# Altium schematic sheet NET.SchDoc(NET)
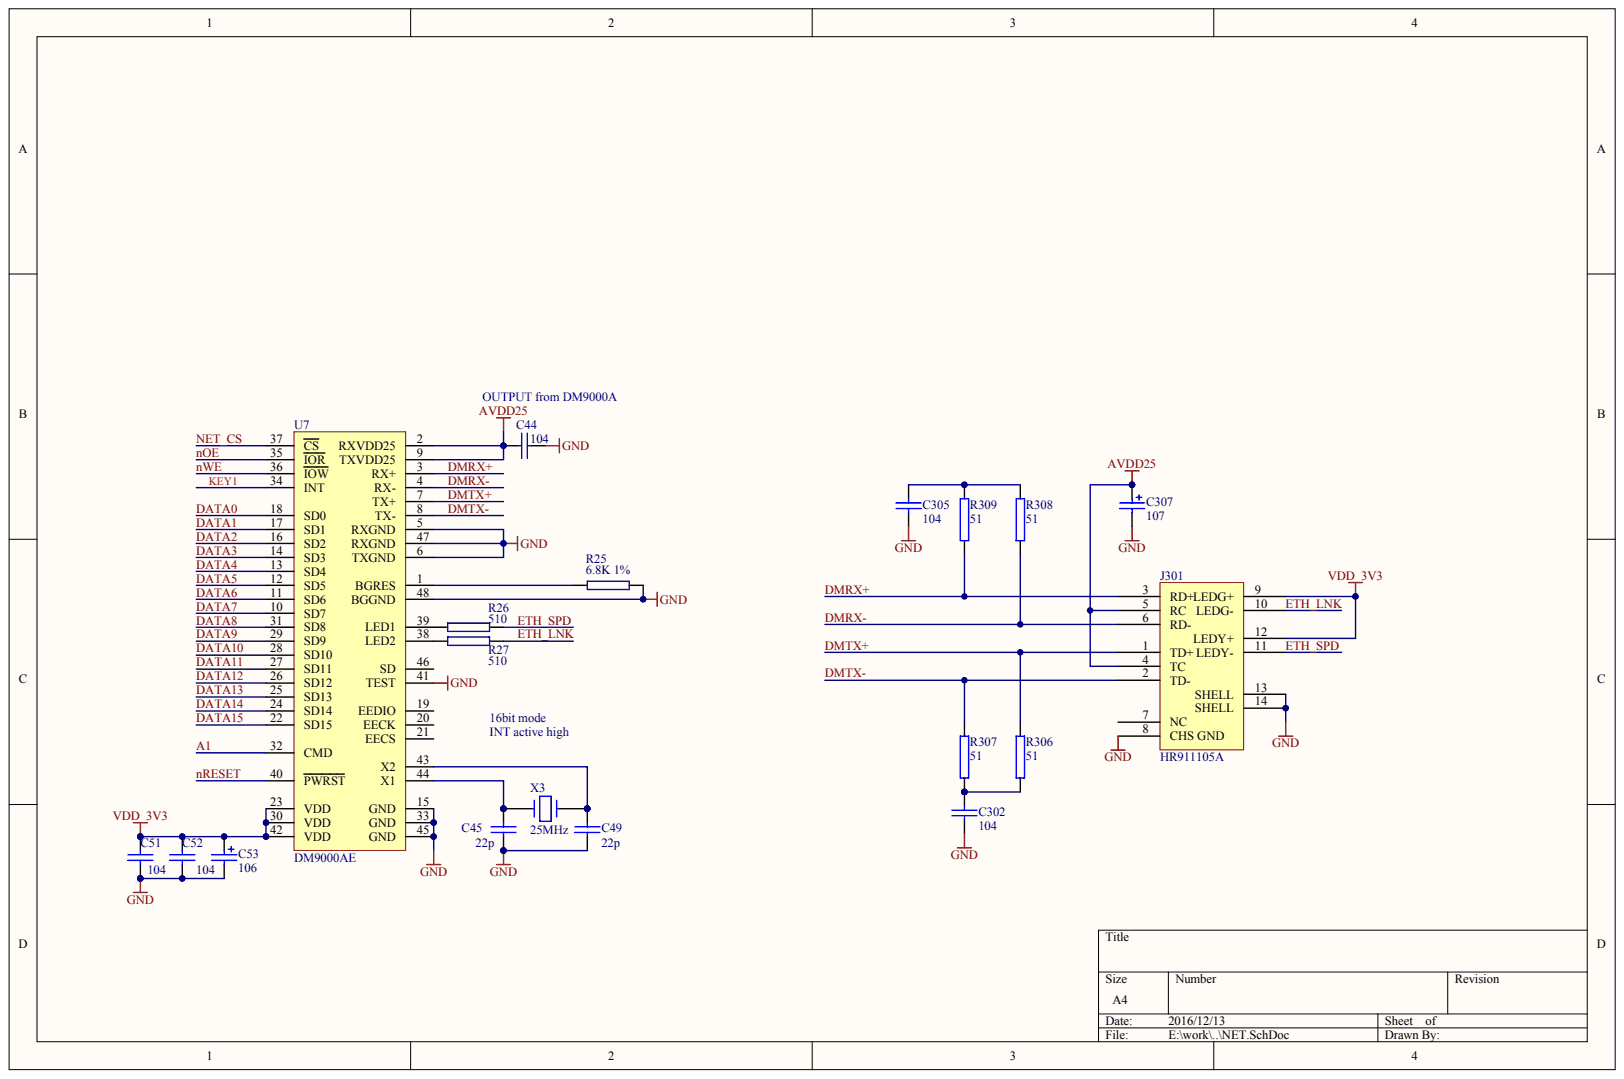
[diagram p0: NET.SchDoc]

## Parts
C44  104
C45  22p
C49  22p
C51  104
C52  104
C53  106
C302  104
C305  104
C307  107
J301  HR911105A
R25  6.8K 1%
R26  510
R27  510
R306  51
R307  51
R308  51
R309  51
U7  DM9000AE
X3  25MHz

## Nets
AVDD25: C44.1 C307.1 J301.4(TC) J301.5(RC) U7.2(RXVDD25) U7.9(TXVDD25)
GND: C44.2 C45.2 C49.2 C51.2 C52.2 C53.2 C302.1 C305.1 C307.2 J301.8(CHS_GND) J301.13(SHELL) J301.14(SHELL) R25.1 U7.5(RXGND) U7.6(TXGND) U7.15(GND) U7.33(GND) U7.41(TEST) U7.45(GND) U7.47(RXGND) U7.48(BGGND)
VDD_3V3: C51.1 C52.1 C53.1 J301.9(LEDG+) J301.12(LEDY+) U7.23(VDD) U7.30(VDD) U7.42(VDD)
A1: U7.32(CMD)
DATA0: U7.18(SD0)
DATA1: U7.17(SD1)
DATA2: U7.16(SD2)
DATA3: U7.14(SD3)
DATA4: U7.13(SD4)
DATA5: U7.12(SD5)
DATA6: U7.11(SD6)
DATA7: U7.10(SD7)
DATA8: U7.31(SD8)
DATA9: U7.29(SD9)
DATA10: U7.28(SD10)
DATA11: U7.27(SD11)
DATA12: U7.26(SD12)
DATA13: U7.25(SD13)
DATA14: U7.24(SD14)
DATA15: U7.22(SD15)
DMRX+: J301.3(RD+) R309.1 U7.3(RX+)
DMRX-: J301.6(RD-) R308.1 U7.4(RX-)
DMTX+: J301.1(TD+) R306.1 U7.7(TX+)
DMTX-: J301.2(TD-) R307.1 U7.8(TX-)
ETH_LNK: J301.10(LEDG-) R27.1
ETH_SPD: J301.11(LEDY-) R26.1
KEY1: U7.34(INT)
NET_CS: U7.37(CS)
NOE: U7.35(IOR)
NRESET: U7.40(PWRST)
NWE: U7.36(IOW)
NetC45_1: C45.1 U7.44(X1) X3.2
NetC49_1: C49.1 U7.43(X2) X3.1
NetC302_2: C302.2 R306.2 R307.2
NetC305_2: C305.2 R308.2 R309.2
NetR25_2: R25.2 U7.1(BGRES)
NetR26_2: R26.2 U7.39(LED1)
NetR27_2: R27.2 U7.38(LED2)

Not connected: J301 7(NC); U7 19(EEDIO) 20(EECK) 21(EECS) 46(SD)
